# Altium schematic sheet FPGA_Prog.SchDoc(U_FPGA_Prog)
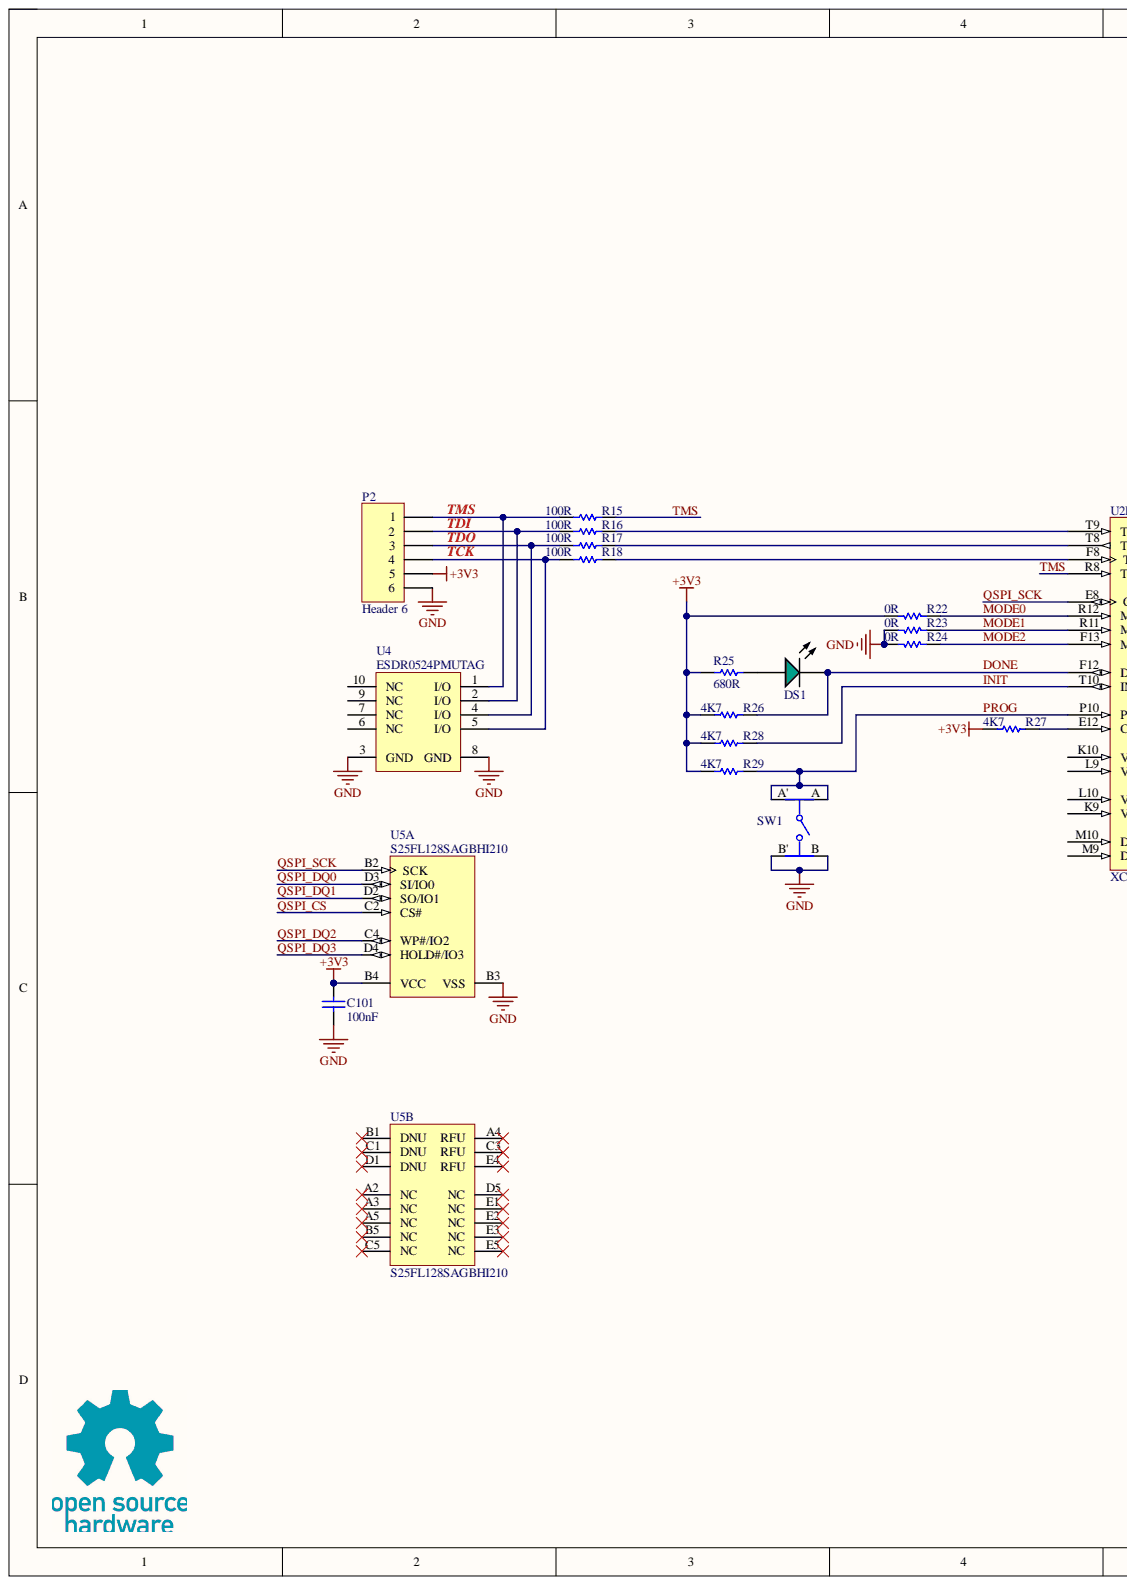
[diagram p0: FPGA_Prog.SchDoc, left]
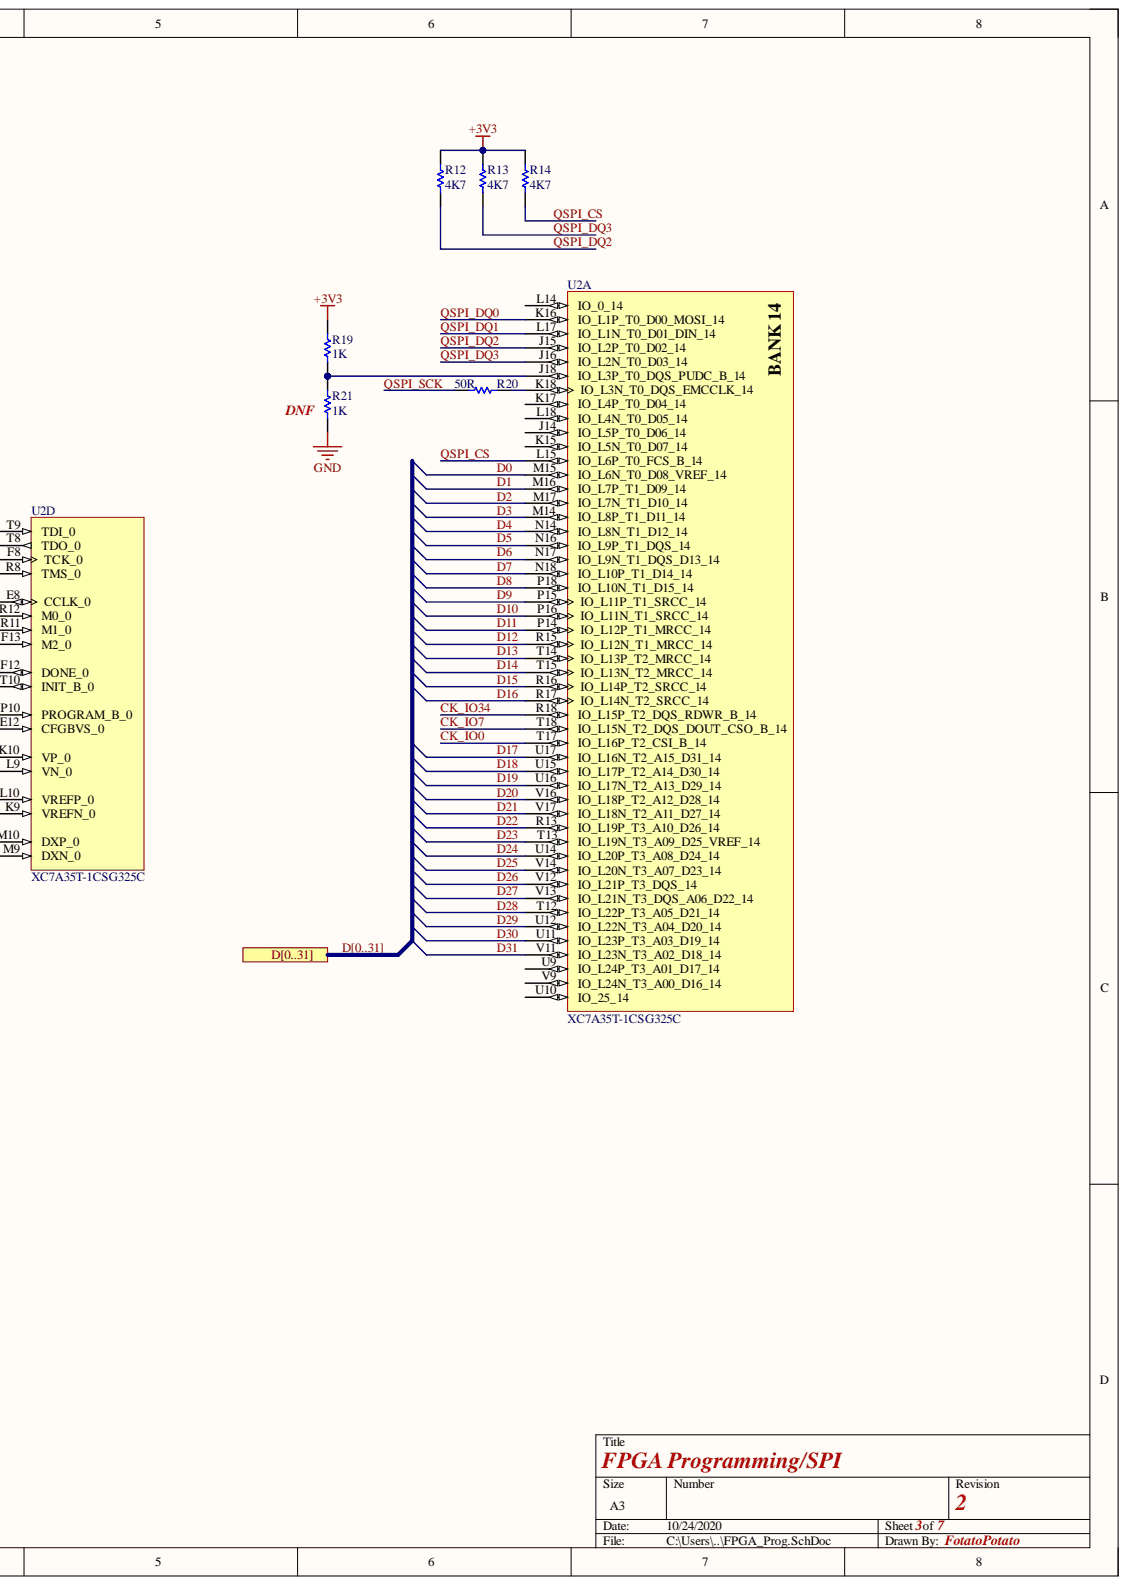
[diagram p1: FPGA_Prog.SchDoc, right]

## Parts
C101  100nF  [CAPC1005X56X25LL10T15]
DS1  HSMG-C190  [AVAG-HSMX-C190_V]  (value not drawn)
P2  Header 6  [HDR1X6]
R12  4K7  [RESC1005X40X25ML05T05]
R13  4K7  [RESC1005X40X25ML05T05]
R14  4K7  [RESC1005X40X25ML05T05]
R15  100R  [RESC1609X50X30NL10T20]
R16  100R  [RESC1609X50X30NL10T20]
R17  100R  [RESC1609X50X30NL10T20]
R18  100R  [RESC1609X50X30NL10T20]
R19  1K  [RESC1005X40X25NL05T05]
R20  50R  [RESC1106X38X25LL20]
R21  1K  [RESC1005X40X25NL05T05]
R22  0R  [RESC1005X40X25ML05T10]
R23  0R  [RESC1005X40X25ML05T10]
R24  0R  [RESC1005X40X25ML05T10]
R25  680R  [RESC1609X50X30ML10T20]
R26  4K7  [RESC1005X40X25ML05T05]
R27  4K7  [RESC1005X40X25ML05T05]
R28  4K7  [RESC1005X40X25ML05T05]
R29  4K7  [RESC1005X40X25ML05T05]
SW1  EVQ-Q2U03W  [FP-EVQQ2-MFG]  (value not drawn)
U2  XC7A35T-1CSG325C  [CSG325]  (parts A,D)
U4  ESDR0524PMUTAG  [ONSC-UDFN-10-517BB-01_V]
U5  S25FL128SAGBHI210  [SPSN-FAB024]  (parts A,B)

## Ports
D0, D1, D2, D3, D4, D5, D6, D7, D8, D9, D10, D11, D12, D13, D14, D15, D16, D17, D18, D19, D20, D21, D22, D23, D24, D25, D26, D27, D28, D29, D30, D31, D[0..31]

## Nets
+3V3: C101.2 P2.5 R12.2 R13.2 R14.2 R19.2 R22.2 R25.1 R26.2 R27.1 R28.2 R29.2 U5.B4(VCC)
GND: C101.1 P2.6 R21.1 R23.2 R24.2 SW1.B(B') SW1.B'(B) U4.3(GND) U4.8(GND) U5.B3(VSS)
CK_IO0: U2.T17(IO_L16P_T2_CSI_B_14)
CK_IO7: U2.T18(IO_L15N_T2_DQS_DOUT_CSO_B_14)
CK_IO34: U2.R18(IO_L15P_T2_DQS_RDWR_B_14)
D0: U2.M15(IO_L6N_T0_D08_VREF_14) ; port D[0..31]  [also labelled D]
D1: U2.M16(IO_L7P_T1_D09_14) ; port D[0..31]  [also labelled D]
D2: U2.M17(IO_L7N_T1_D10_14) ; port D[0..31]  [also labelled D]
D3: U2.M14(IO_L8P_T1_D11_14) ; port D[0..31]  [also labelled D]
D4: U2.N14(IO_L8N_T1_D12_14) ; port D[0..31]  [also labelled D]
D5: U2.N16(IO_L9P_T1_DQS_14) ; port D[0..31]  [also labelled D]
D6: U2.N17(IO_L9N_T1_DQS_D13_14) ; port D[0..31]  [also labelled D]
D7: U2.N18(IO_L10P_T1_D14_14) ; port D[0..31]  [also labelled D]
D8: U2.P18(IO_L10N_T1_D15_14) ; port D[0..31]  [also labelled D]
D9: U2.P15(IO_L11P_T1_SRCC_14) ; port D[0..31]  [also labelled D]
D10: U2.P16(IO_L11N_T1_SRCC_14) ; port D[0..31]  [also labelled D]
D11: U2.P14(IO_L12P_T1_MRCC_14) ; port D[0..31]  [also labelled D]
D12: U2.R15(IO_L12N_T1_MRCC_14) ; port D[0..31]  [also labelled D]
D13: U2.T14(IO_L13P_T2_MRCC_14) ; port D[0..31]  [also labelled D]
D14: U2.T15(IO_L13N_T2_MRCC_14) ; port D[0..31]  [also labelled D]
D15: U2.R16(IO_L14P_T2_SRCC_14) ; port D[0..31]  [also labelled D]
D16: U2.R17(IO_L14N_T2_SRCC_14) ; port D[0..31]  [also labelled D]
D17: U2.U17(IO_L16N_T2_A15_D31_14) ; port D[0..31]  [also labelled D]
D18: U2.U15(IO_L17P_T2_A14_D30_14) ; port D[0..31]  [also labelled D]
D19: U2.U16(IO_L17N_T2_A13_D29_14) ; port D[0..31]  [also labelled D]
D20: U2.V16(IO_L18P_T2_A12_D28_14) ; port D[0..31]  [also labelled D]
D21: U2.V17(IO_L18N_T2_A11_D27_14) ; port D[0..31]  [also labelled D]
D22: U2.R13(IO_L19P_T3_A10_D26_14) ; port D[0..31]  [also labelled D]
D23: U2.T13(IO_L19N_T3_A09_D25_VREF_14) ; port D[0..31]  [also labelled D]
D24: U2.U14(IO_L20P_T3_A08_D24_14) ; port D[0..31]  [also labelled D]
D25: U2.V14(IO_L20N_T3_A07_D23_14) ; port D[0..31]  [also labelled D]
D26: U2.V12(IO_L21P_T3_DQS_14) ; port D[0..31]  [also labelled D]
D27: U2.V13(IO_L21N_T3_DQS_A06_D22_14) ; port D[0..31]  [also labelled D]
D28: U2.T12(IO_L22P_T3_A05_D21_14) ; port D[0..31]  [also labelled D]
D29: U2.U12(IO_L22N_T3_A04_D20_14) ; port D[0..31]  [also labelled D]
D30: U2.U11(IO_L23P_T3_A03_D19_14) ; port D[0..31]  [also labelled D]
D31: U2.V11(IO_L23N_T3_A02_D18_14) ; port D[0..31]  [also labelled D]
DONE: DS1.2 R26.1 U2.F12(DONE_0)
INIT: R28.1 U2.T10(INIT_B_0)
MODE0: R22.1 U2.R12(M0_0)
MODE1: R23.1 U2.R11(M1_0)
MODE2: R24.1 U2.F13(M2_0)
PROG: R29.1 SW1.A SW1.A' U2.P10(PROGRAM_B_0)
QSPI_CS: R14.1 U2.L15(IO_L6P_T0_FCS_B_14) U5.C2(CS#)
QSPI_DQ0: U2.K16(IO_L1P_T0_D00_MOSI_14) U5.D3(SI/IO0)
QSPI_DQ1: U2.L17(IO_L1N_T0_D01_DIN_14) U5.D2(SO/IO1)
QSPI_DQ2: R12.1 U2.J15(IO_L2P_T0_D02_14) U5.C4(WP#/IO2)
QSPI_DQ3: R13.1 U2.J16(IO_L2N_T0_D03_14) U5.D4(HOLD#/IO3)
QSPI_SCK: R20.1 U2.E8(CCLK_0) U5.B2(SCK)
TMS: R15.2 U2.R8(TMS_0)
NetDS1_1: DS1.1 R25.2
NetP2_1: P2.1 R15.1 U4.1(I/O)
NetP2_2: P2.2 R16.1 U4.2(I/O)
NetP2_3: P2.3 R17.1 U4.4(I/O)
NetP2_4: P2.4 R18.1 U4.5(I/O)
NetR16_2: R16.2 U2.T9(TDI_0)
NetR17_2: R17.2 U2.T8(TDO_0)
NetR18_2: R18.2 U2.F8(TCK_0)
NetR19_1: R19.1 R21.2 U2.J18(IO_L3P_T0_DQS_PUDC_B_14)
NetR20_2: R20.2 U2.K18(IO_L3N_T0_DQS_EMCCLK_14)
NetR27_2: R27.2 U2.E12(CFGBVS_0)

Not connected: U2 J14(IO_L5P_T0_D06_14) K9(VREFN_0) K10(VP_0) K15(IO_L5N_T0_D07_14) K17(IO_L4P_T0_D04_14) L9(VN_0) L10(VREFP_0) L14(IO_0_14) L18(IO_L4N_T0_D05_14) M9(DXN_0) M10(DXP_0) U9(IO_L24P_T3_A01_D17_14) U10(IO_25_14) V9(IO_L24N_T3_A00_D16_14); U4 6(NC) 7(NC) 9(NC) 10(NC); U5 A2(NC) A3(NC) A4(RFU) A5(NC) B1(DNU) B5(NC) C1(DNU) C3(RFU) C5(NC) D1(DNU) D5(NC) E1(NC) E2(NC) E3(NC) E4(RFU) E5(NC)

## Library data of the parts
C101 = CMP-2008-02743-1: Manufacturer: AVX Interconnect / Elco; Part Number: 04026D104KAT2A; Component Kind: Standard; Library Name: Altium Content Vault
DS1 = CMP-1744-00002-1: Chip LED, Green, 2.2 V, -40 to 85 degC, 2-Pin SMD, RoHS, Tape and Reel; Access Time: 3500 ns; Case\Package: SOIC; Clock Frequency: 100 KHz; Density: 32 kb; Frequency: 400 KHz; Interface: Serial; Max Supply Voltage: 5.5 V; Min Supply Voltage: 1.7 V; Nominal Supply Current: 2 mA; Component Kind: Standard; ComponentLink1Description: Manufacturer URL; ComponentLink1URL: http://www.avagotech.com/; ComponentLink2Description: Datasheet; ComponentLink2URL: http://docs.avagotech.com/docs/AV02-0551EN; ComponentLink3Description: Package Specification; ComponentLink3URL: http://www.fairchildsemi.com/dwg/MA/MA03D.pdf; DatasheetVersion: Mar-12; Height: 1.5 mm; Length: 5 mm; Library Name: Altium Content Vault; Max Clock Frequency: 100 KHz; Max Operating Temperature: 85 degC; Min Operating Temperature: -40 degC; Mount: Surface Mount; Package Quantity: 3000; PackageDescription: 2-Pin Surface Mount Device, Body 1.6 x 0.8 mm, Height 0.9 mm; PackageReference: HSMX-C190; Packaging: Tape and Reel; Pins: 8; Radiation Hardening: No; REACH SVHC: No SVHC; RoHSCompliant: true; Width: 4 mm
P2 = Header 6: Header, 6-Pin; Component Kind: Standard; LatestRevisionDate: 17-Jul-2002; LatestRevisionNote: Re-released for DXP Platform.; Library Name: Miscellaneous Connectors.IntLib; Signal Integrity: Connector; Publisher: Altium Limited
R12-R14 R26-R29 = CMP-2002-00053-1: Manufacturer: Vishay BCcomponents; Part Number: CRCW04024K70JNED; Component Kind: Standard; Library Name: Altium Content Vault
R15-R18 = CMP-2000-03824-1: Manufacturer: Vishay Dale; Part Number: CRCW0603100RFKEA; Component Kind: Standard; Library Name: Altium Content Vault
R19 R21 = CMP-2002-08276-1: Manufacturer: Vishay Dale; Part Number: CRCW04021K00FKED; Component Kind: Standard; Library Name: Altium Content Vault
R20 = CMP-2002-03627-1: Manufacturer: Vishay Dale; Part Number: FC0402E50R0BST1; Component Kind: Standard; Library Name: Altium Content Vault
R22-R24 = CMP-2002-03041-1: Manufacturer: Vishay Dale; Part Number: CRCW04020000Z0EDHP; Component Kind: Standard; Library Name: Altium Content Vault
R25 = CMP-2000-03529-1: Manufacturer: Vishay Dale; Part Number: CRCW0603680RFKEA; Component Kind: Standard; Library Name: Altium Content Vault
SW1 = CMP-05618-000012-1: SWITCH TACTILE SPST-NO 0.02A 15V; Manufacturer: Panasonic; Contact Current Rating: 20mA; Contact Resistance: 100mΩ; Electromechanical Life: 200000Cycles; Operating Force: 2.6N; Automotive: No; Category: Switch; Component Kind: Standard; ComponentLink2Description: Datasheet; ComponentLink2URL: https://industrial.panasonic.com/cdbs/www-data/pdf/ATK0000/ATK0000C360.pdf; Device Class L1: Electromechanical; Device Class L2: Switches; Device Class L3: Tactile Switches; Digikey Description: SWITCH TACTILE SPST-NO 0.02A 15V; Height: 3.3mm; Lead Free: Yes; Library Name: Altium Content Vault; Manufacturer Part Number: EVQ-Q2U03W; Mount: Surface Mount; Mouser Description: Tactile Switches 2.6NF 6.5x6.0x3.1mm; Octopart: https://octopart.com/evq-q2u03w-panasonic-1404553; Package: EVQQ2; RoHS: TRUE; Temperature: -40°C to +85°C; Temperature Range High: +85°C; Temperature Range Low: -40°C; Throw Configuration: SPST-NO; Voltage Rating Dc: 15V
U2 = CMP-0383-00016-1: Artix-7 FPGA, 150 User I/Os, 4 GTP, 324-Ball BGA, Speed Grade 1, Commercial Grade, Pb-Free; Manufacturer: Xilinx; Available User I/O: 150; CMTs: 5; GTP: 4; Logic Cells: 33280; Speed Grades: 1; Total Block RAM: 1800 Kb; Component Kind: Standard; ComponentLink1Description: Manufacturer URL; ComponentLink1URL: http://www.xilinx.com/; ComponentLink2Description: Datasheet; ComponentLink2URL: http://www.xilinx.com/support/documentation/data_sheets/ds180_7Series_Overview.pdf; ComponentLink3Description: Pinout; ComponentLink3URL: http://www.xilinx.com/support/documentation/user_guides/ug475_7Series_Pkg_Pinout.pdf; ComponentLink4Description: Package Specification; ComponentLink4URL: http://www.xilinx.com/support/documentation/user_guides/ug475_7Series_Pkg_Pinout.pdf; DatasheetVersion: Rev. 1.15; Library Name: Altium Content Vault; Maximum Distributed RAM: 400 Kb; Mounting Technology: Surface Mount; Operating Temperature Range: Commercial; PackageDescription: 324-Ball Wire-Bond Chip-Scale BGA, Body 15 x 15 mm, Pitch 0.8 mm; PackageReference: CSG-325; PackageVersion: Rev. 1.11; PartNumber: XC7A35T-1CSG325C
U4 = CMP-1063-00245-1: Transient Voltage Suppressor, Low Capacitance ESD Protection for High Speed Data, 10-Pin UDFN, Pb-Free, Tape and Reel; Manufacturer: On Semiconductor; Direction: Unidirectional; IR Max (uA): 1; Number of Lines: 4; V(BR) Min (V): 5.5; VRWM Max (V): 5; Component Kind: Standard; ComponentLink1Description: Manufacturer URL; ComponentLink1URL: http://www.onsemi.com/; ComponentLink2Description: Datasheet; ComponentLink2URL: http://www.onsemi.cn/pub_link/Collateral/ESDR0524P-D.PDF; ComponentLink3Description: Package Specification; ComponentLink3URL: http://www.onsemi.com/pub_link/Collateral/517BB.PDF; DatasheetVersion: Rev. 4; Library Name: Altium Content Vault; Mounting Technology: Surface Mount; PackageDescription: 10-Pin Small Outline No-Lead, Body 2.5 x 1 mm, Pitch 0.5 mm; PackageReference: UDFN-10-517BB-01; PackageVersion: Rev. O, 11/2009; Packing: Tape and Reel; PartNumber: ESDR0524PMUTAG; RoHS: Pb-Free
U5 = CMP-1329-00008-1: MirrorBit Flash Non-Volatile Memory CMOS 3.0 Volt Core with Versatile I/O, Serial Peripheral Interface with Multi-I/O, 128 Mbit, 133 MHz, -40 to 85 degC, 24-Ball BGA (FAB024), RoHS, Tray; Manufacturer: Spansion; OTP: 1024 B; Speed: 133 MHz; VCC: 2.7 to 3.6 V; Component Kind: Standard; ComponentLink1Description: Manufacturer URL; ComponentLink1URL: http://www.spansion.com/Pages/Default.aspx; ComponentLink2Description: Datasheet; ComponentLink2URL: http://www.spansion.com/Support/Datasheets/S25FL128S_256S_00.pdf; ComponentLink3Description: Package Specification; ComponentLink3URL: http://www.spansion.com/Support/Datasheets/S25FL128S_256S_00.pdf; DatasheetVersion: Rev. 08; Density: 128 Mbit; Library Name: Altium Content Vault; Mounting Technology: Surface Mount; PackageDescription: 24-Ball Ball Grid Array, Body 8 x 6 mm, Pitch 1 mm; Packing: Tray; PartNumber: S25FL128SAGBHI210; RoHS: TRUE; Temperature Range: -40 to 85 degC
